# Altium schematic sheet [28] - PWR III.SchDoc("[28] - PWR III")
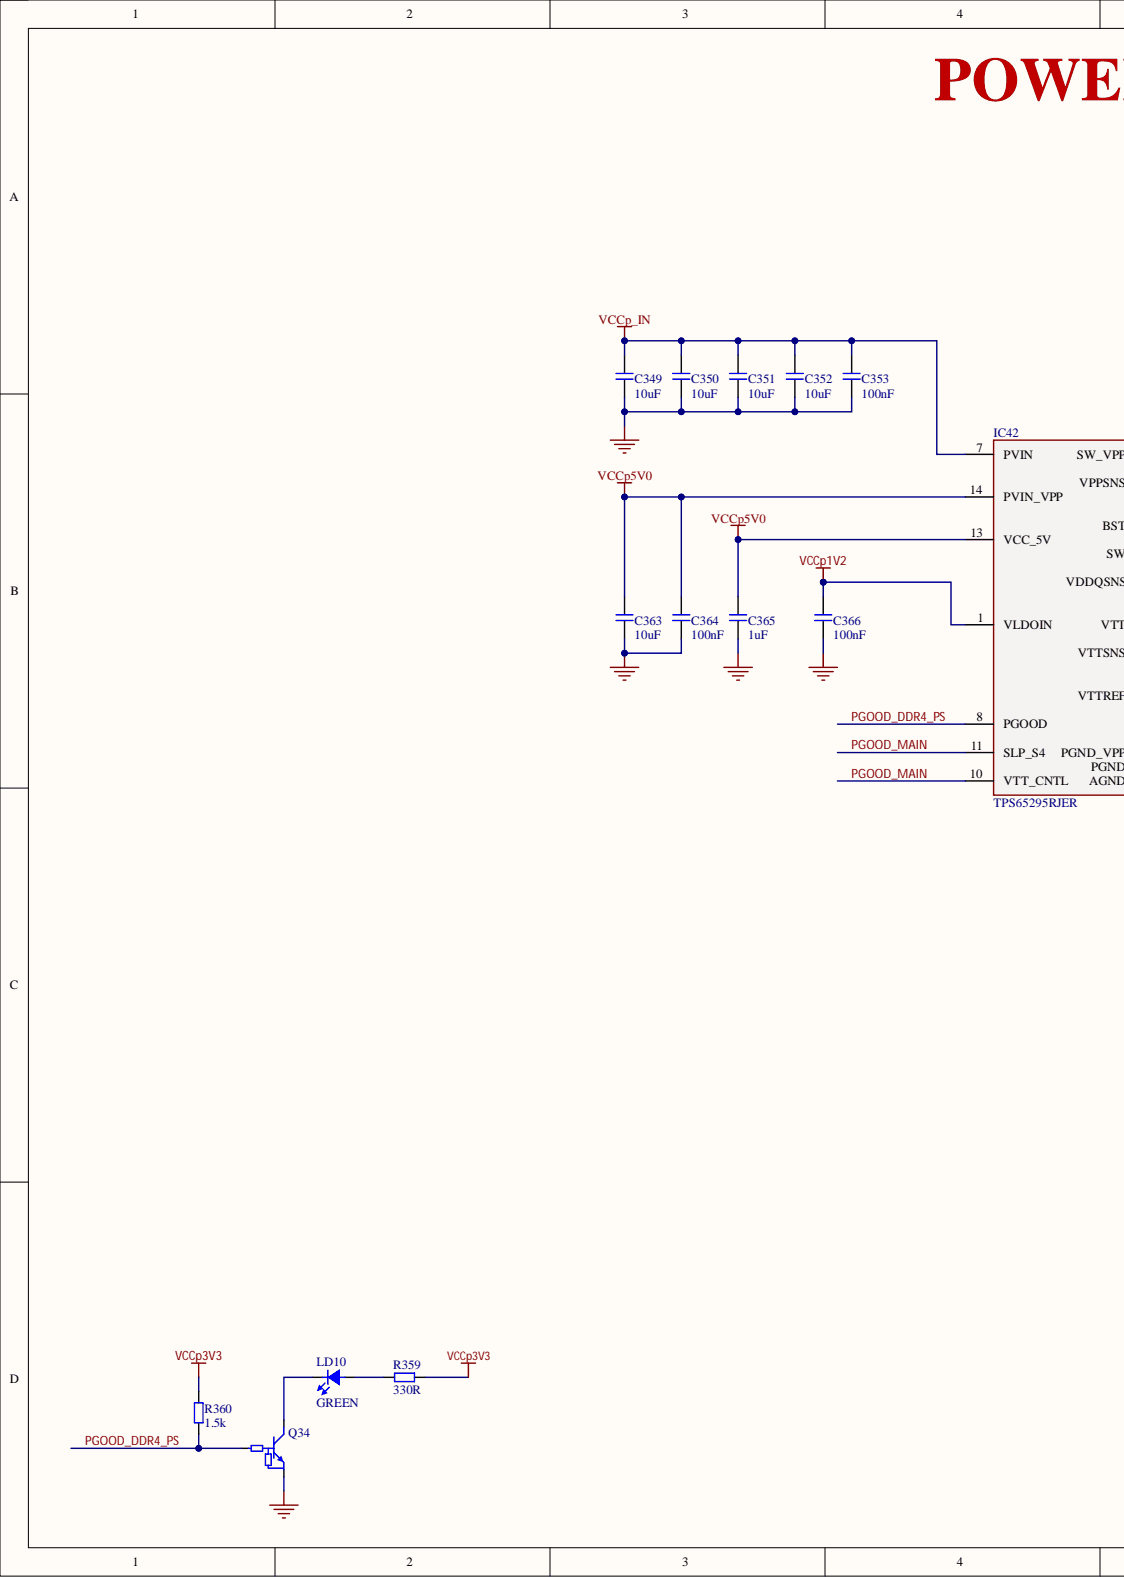
[diagram p0: [28] - PWR III.SchDoc, left]
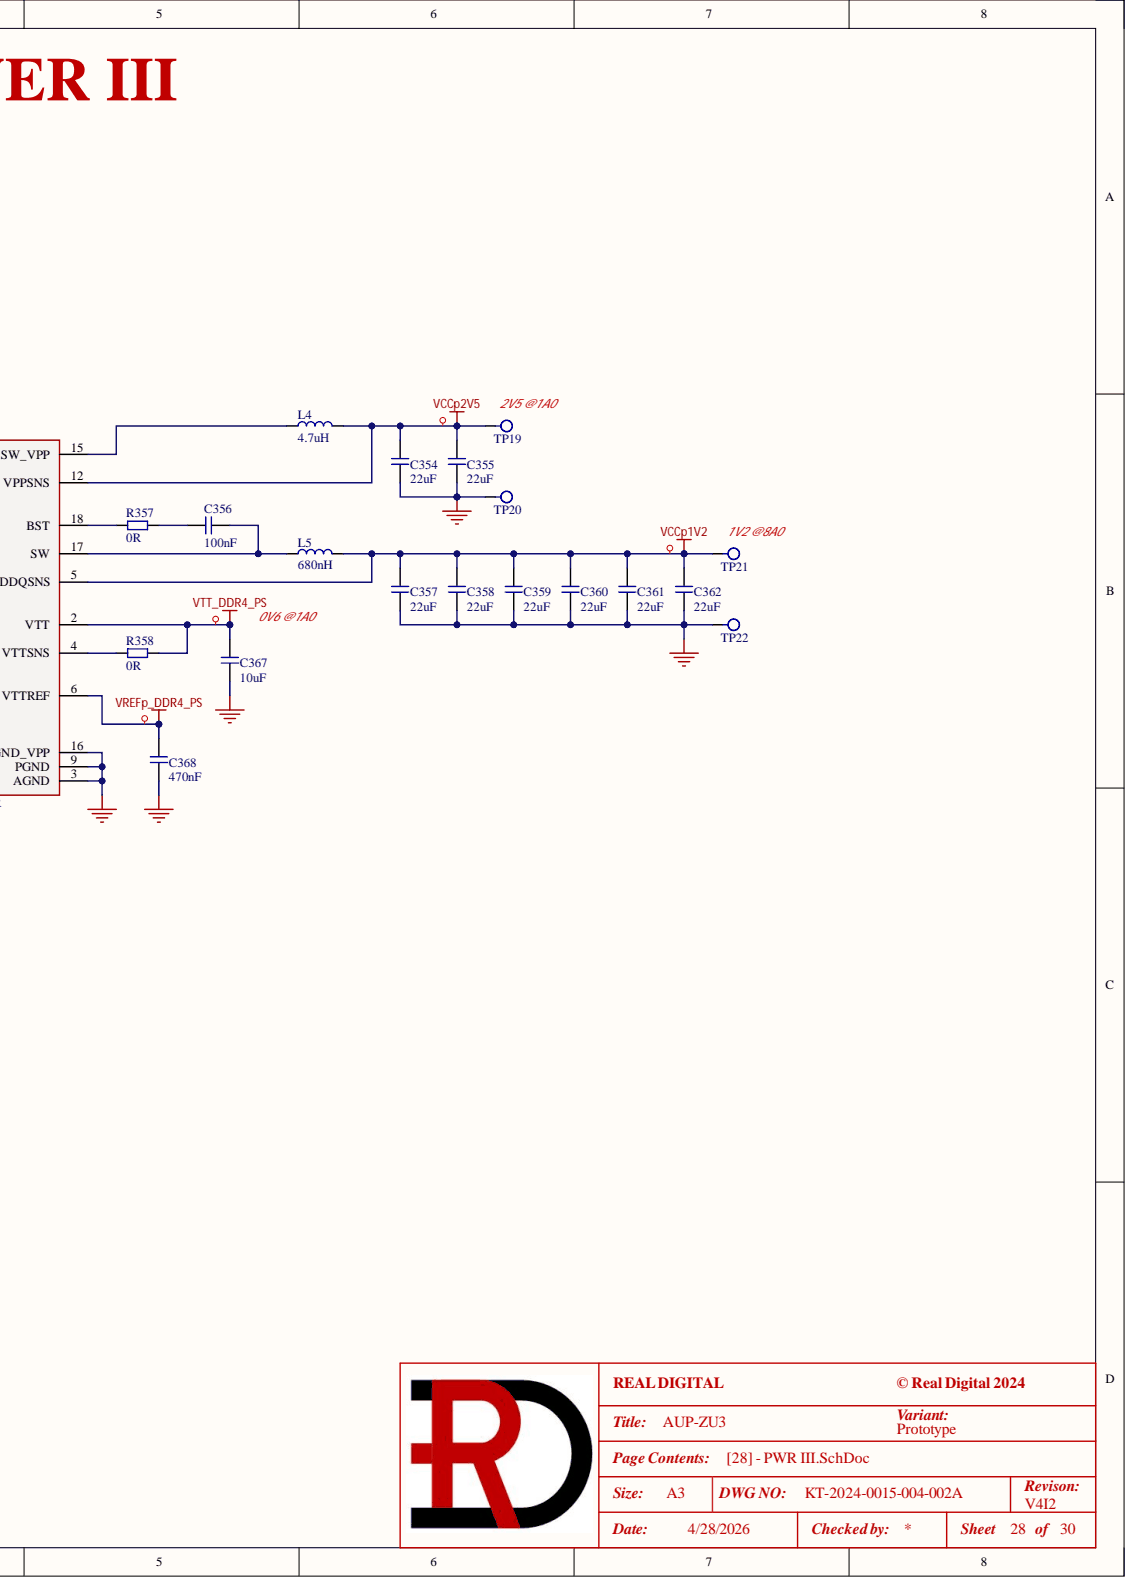
[diagram p1: [28] - PWR III.SchDoc, right]

## Parts
C349  10uF  [C0805]
C350  10uF  [C0805]
C351  10uF  [C0805]
C352  10uF  [C0805]
C353  100nF  [C0402]
C354  22uF  [C0805]
C355  22uF  [C0805]
C356  100nF  [C0402]
C357  22uF  [C0805]
C358  22uF  [C0805]
C359  22uF  [C0805]
C360  22uF  [C0805]
C361  22uF  [C0805]
C362  22uF  [C0805]
C363  10uF  [C0805]
C364  100nF  [C0402]
C365  1uF  [C0603]
C366  100nF  [C0402]
C367  10uF  [C0805]
C368  470nF  [C0603]
IC42  TPS65295RJER  [RJE0018B - TI]
L4  4.7uH  [XAL5030]
L5  680nH  [XGL6030]
LD10  GREEN  [LD0603_Green]
Q34  DTC043ZEBTL  [SC89-EMT3F]  (value not drawn)
R357  0R  [R0402]
R358  0R  [R0402]
R359  330R  [R0402]
R360  1.5k  [R0402]
TP19  1.5mm  [TP_1.5MM]  (value not drawn)  (no BOM)
TP20  1.5mm  [TP_1.5MM]  (value not drawn)  (no BOM)
TP21  1.5mm  [TP_1.5MM]  (value not drawn)  (no BOM)
TP22  1.5mm  [TP_1.5MM]  (value not drawn)  (no BOM)

## Nets
GND: C349.1 C350.1 C351.1 C352.1 C353.1 C354.1 C355.1 C357.1 C358.1 C359.1 C360.1 C361.1 C362.1 C363.1 C364.1 C365.1 C366.1 C367.1 C368.1 IC42.3(AGND) IC42.9(PGND) IC42.16(PGND_VPP) Q34.GND TP20.1 TP22.1
VCCp1V2: C357.2 C358.2 C359.2 C360.2 C361.2 C362.2 C366.2 IC42.1(VLDOIN) IC42.5(VDDQSNS) L5.2 TP21.1
VCCp2V5: C354.2 C355.2 IC42.12(VPPSNS) L4.2 TP19.1
VCCp3V3: R359.2 R360.2
VCCp5V0: C363.2 C364.2 C365.2 IC42.13(VCC_5V) IC42.14(PVIN_VPP)
VCCp_IN: C349.2 C350.2 C351.2 C352.2 C353.2 IC42.7(PVIN)
VREFp_DDR4_PS: C368.2 IC42.6(VTTREF)
VTT_DDR4_PS: C367.2 IC42.2(VTT) R358.2
PGOOD_DDR4_PS: IC42.8(PGOOD) Q34.IN R360.1
PGOOD_MAIN: IC42.10(VTT_CNTL) IC42.11(SLP_S4)
NetC356_1: C356.1 IC42.17(SW) L5.1
NetC356_2: C356.2 R357.2
NetIC42_4: IC42.4(VTTSNS) R358.1
NetIC42_15: IC42.15(SW_VPP) L4.1
NetIC42_18: IC42.18(BST) R357.1
NetLD10_A: LD10.A R359.1
NetLD10_K: LD10.K Q34.OUT

## Library data of the parts
C349-C351 = GRM21BR61H106KE43K: CAP CER 10uF 50V X5R 0805; Manufacturer 1: Murata Electronics; .Checked_PCB: RV; C P/N: RD-111-0102; LoadStatus: Load; Tolerance: 10%; Category: Capacitors; Component Kind: Standard; Library Name: KT-RealDigital.IntLib; Manufacturer 1 Part Number: GRM21BR61H106KE43K; Temperature Coefficient: X5R; Voltage - Rated: 50V; .Checked_SCH: RV; .Creator: RV
C352 C363 C367 = GRM21BR71A106KA73L: CAP CER 10uF 10V X7R 0805; Manufacturer 1: Murata Electronics; .Checked_PCB: AC; .Creator: RV; C P/N: 111-0789; LoadStatus: Load; Tolerance: 10%; Category: Capacitors; Component Kind: Standard; Library Name: KT-RealDigital.IntLib; Manufacturer 1 Part Number: GRM21BR71A106KA73L; Temperature Coefficient: X7R; Voltage - Rated: 10V; .Checked_SCH: AC
C353 C364 C366 = GRM155R71H104ME14J: CAP CER 0.1uF 50V X7R 0402; Manufacturer 1: Murata Electronics; .Checked_PCB: DG; .Creator: RV; C P/N: RD-111-0069; LoadStatus: Load; Tolerance: 20%; Category: Capacitors; Component Kind: Standard; Library Name: KT-RealDigital.IntLib; Manufacturer 1 Part Number: GRM155R71H104ME14J; Temperature Coefficient: X7R; Voltage - Rated: 50V; .Checked_SCH: DG
C354 C355 C357-C362 = GRM21BR61E226ME44K: CAP CER 22uF 25V X5R 0805; Manufacturer 1: Murata Electronics; .Checked_PCB: RV; C P/N: RD-111-0047; LoadStatus: Load; Tolerance: 20%; Category: Capacitors; Component Kind: Standard; Library Name: KT-RealDigital.IntLib; Manufacturer 1 Part Number: GRM21BR61E226ME44K; Temperature Coefficient: X5R; Voltage - Rated: 25V; .Checked_SCH: RV; .Creator: RV
C356 = GRM155R71E104KE14J: CAP CER 0.1uF 25V X7R 0402; Manufacturer 1: Murata Electronics; .Checked_PCB: AC; .Creator: RV; C P/N: RD-111-0006; LoadStatus: Load; Tolerance: 10%; Category: Capacitors; Component Kind: Standard; Library Name: KT-RealDigital.IntLib; Manufacturer 1 Part Number: GRM155R71E104KE14J; Temperature Coefficient: X7R; Voltage - Rated: 25V; .Checked_SCH: AC
C365 = GRT188R61H105KE13D: CAP CER 1uF 50V X5R 0603; Manufacturer 1: Murata Electronics; .Checked_PCB: RV; C P/N: CY-111-0264; LoadStatus: Load; Tolerance: 10%; Category: Capacitors; Component Kind: Standard; Library Name: KT-RealDigital.IntLib; Manufacturer 1 Part Number: GRT188R61H105KE13D; Temperature Coefficient: X5R; Voltage - Rated: 50V; .Checked_SCH: RV; .Creator: RV
C368 = GRM188R71E474KA12D: CAP CER 0.47uF 25V X7R 0603; Manufacturer 1: Murata Electronics; .Checked_PCB: AC; .Creator: RV; C P/N: RD-111-0008; LoadStatus: Load; Tolerance: 10%; Category: Capacitors; Component Kind: Standard; Library Name: KT-RealDigital.IntLib; Manufacturer 1 Part Number: GRM188R71E474KA12D; Temperature Coefficient: X7R; Voltage - Rated: 25V; .Checked_SCH: AC
IC42 = TPS65295RJER: IC PWR MGMT SWITCHING REGULATOR; Manufacturer 1: Texas Instruments; .Checked_PCB: RV; .Creator: DG; C P/N: RD-126-1526; LoadStatus: Load; Category: IC; Component Kind: Standard; Library Name: KT-RealDigital.IntLib; Manufacturer 1 Part Number: TPS65295RJER; .Checked_SCH: RV
L4 = XAL5030-472MEC: Fixed Inductors 4.7uH Shld 20% 5.9A 40mOhms AECQ2; Manufacturer 1: Coilcraft; .Checked_PCB: RV; C P/N: RD-131-0042; LoadStatus: Load; Category: Inductors; Component Kind: Standard; Library Name: KT-RealDigital.IntLib; Manufacturer 1 Part Number: XAL5030-472MEC; .Checked_SCH: RV; .Creator: RV
L5 = XGL6030-681MEC: Fixed Inductors 680nH Shld 20% 22.5A 3.5mOhms AECQ2; Manufacturer 1: Coilcraft; .Checked_PCB: RV; C P/N: RD-131-0041; LoadStatus: Load; Category: Inductors; Component Kind: Standard; Library Name: KT-RealDigital.IntLib; Manufacturer 1 Part Number: XGL6030-681MEC; .Checked_SCH: RV; .Creator: RV
LD10 = LED_GREEN: LED GREEN 0603; Manufacturer 1: Everlight Electronics CO., LTD; Manufacturer 1 Part Number: 19-21SYGC/S530-E4/TR8; .Checked_PCB: AC; .Creator: RV; C P/N: 121-0006; LoadStatus: Load; Category: Led; Component Kind: Standard; Library Name: KT-RealDigital.IntLib; .Checked_SCH: AC
Q34 = DTC043ZEBTL: TRANS PREBIAS NPN 50V EMT3F; Manufacturer 1: Rohm Semiconductor; .Checked_PCB: RV; C P/N: RD-121-0006; LoadStatus: Load; Category: BJTs; Component Kind: Standard; Library Name: KT-RealDigital.IntLib; Manufacturer 1 Part Number: DTC043ZEBTL; .Checked_SCH: RV; .Creator: RV
R357 R358 = RC0402FR-070RL: RES SMD 0 OHM JUMPER 1/16W 0402; Manufacturer 1: YAGEO; .Checked_PCB: AC; .Creator: RV; C P/N: RD-101-0003; LoadStatus: Load; Category: Resistors; Component Kind: Standard; Library Name: KT-RealDigital.IntLib; Manufacturer 1 Part Number: RC0402FR-070RL; Tolerance: Jumper; .Checked_SCH: AC
R359 = RC0402JR-07330RL: RES SMD 330 OHM 5% 1/16W 0402; Manufacturer 1: Yageo; .Checked_PCB: AC; .Creator: RV; C P/N: 101-0112; LoadStatus: Load; Tolerance: 5%/TK100; Category: Resistors; Component Kind: Standard; Library Name: KT-RealDigital.IntLib; Manufacturer 1 Part Number: RC0402JR-07330RL; .Checked_SCH: AC
R360 = RC0402FR-071K5L: RES SMD 1.5K OHM 1% 1/16W 0402; Manufacturer 1: YAGEO; .Checked_PCB: RV; C P/N: RD-101-0082; LoadStatus: Load; Tolerance: 1%/TK100; Category: Resistors; Component Kind: Standard; Library Name: KT-RealDigital.IntLib; Manufacturer 1 Part Number: RC0402FR-071K5L; .Checked_SCH: RV; .Creator: RV
TP19-TP22 = TEST_POINT_1.5mm: TEST POINT - Round 1.5mm; Manufacturer 1: NA; .Checked_PCB: RV; LoadStatus: Load; Category: Test Point; Library Name: KT-RealDigital.IntLib; Manufacturer 1 Part Number: NA; Manufacturer 2: NA; Manufacturer 2 Part Number: NA; .Checked_SCH: RV; .Creator: RV
